# Altium schematic sheet [03] - 28PINS SCHEMATIC.SchDoc("[03] - 28PINS SCHEMATIC") (continued)
## Library data of the parts (continued)
U4-U7 = SN74AUC1G08DBVR: LOGIC, 74AUC1G, AND GATE, SOT23-5; Manufacturer: Texas Instruments; High Level Output Current: -9mA; Low Level Output Current: 9mA; Max Supply Voltage: 2.7V; Min Supply Voltage: 800mV; Number of Bits: 1; Number of Input Lines: 2; Propagation Delay: 2ns; Quiescent Current: 10uA; Schmitt Trigger Input: No; Turn-On Delay Time: 2.4ns; Input Type: DIFFERENTIAL; Case/Package: SOT-23; Category: Logic Gates; Component Kind: Standard; ComponentLink1Description: https://datasheet.ciiva.com/4216/1146sn74auc1g08-4216507.pdf?src-supplier=Arrow+Electronics; ComponentLink1URL: https://datasheet.ciiva.com/4216/1146sn74auc1g08-4216507.pdf?src-supplier=Arrow+Electronics; ComponentLink2Description: https://datasheet.ciiva.com/6124/1775236-6124395.pdf?src-supplier=Farnell; ComponentLink2URL: https://datasheet.ciiva.com/6124/1775236-6124395.pdf?src-supplier=Farnell; ComponentLink3Description: https://datasheet.ciiva.com/23844/1634288-23844654.pdf?src-supplier=Newark; ComponentLink3URL: https://datasheet.ciiva.com/23844/1634288-23844654.pdf?src-supplier=Newark; ComponentLink4Description: https://datasheet.ciiva.com/1864/sn74auc1g08-1864662.pdf?src-supplier=Digi-Key; ComponentLink4URL: https://datasheet.ciiva.com/1864/sn74auc1g08-1864662.pdf?src-supplier=Digi-Key; ComponentLink5Description: https://datasheet.ciiva.com/1864/sn74auc1g08-1864663.pdf?src-supplier=Digi-Key; ComponentLink5URL: https://datasheet.ciiva.com/1864/sn74auc1g08-1864663.pdf?src-supplier=Digi-Key; ComponentLink6Description: https://datasheet.ciiva.com/1864/sn74auc1g08-1864664.pdf?src-supplier=Digi-Key; ComponentLink6URL: https://datasheet.ciiva.com/1864/sn74auc1g08-1864664.pdf?src-supplier=Digi-Key; ComponentLink7Description: https://datasheet.ciiva.com/14292/sn74auc1g08-14292269.pdf?src-supplier=Avnet; ComponentLink7URL: https://datasheet.ciiva.com/14292/sn74auc1g08-14292269.pdf?src-supplier=Avnet; Contact Plating: Gold; Height: 1.3mm; Lead Free: Lead Free; Length: 3.05mm; Library Name: Schlib1.SchLib; Logic Function: AND GATE; Manufacturer Part Number: SN74AUC1G08DBVR; Max Operating Temperature: 85°C; Max Output Current: 9mA; Min Operating Temperature: -40°C; Mount: Surface Mount; Number of Circuits: 1; Number of Elements: 1; Number of Gates: 1; Number of Output Lines: 1; Number of Outputs: 1; Number of Pins: 5; Number of Inputs: 2; Output Current: 9mA; Package Quantity: 3000; Packaging: Tape and Reel; Pricing 1: 1=0,43000, 10=0,34900, 25=0,31920, 100=0,23740, 250=0,21520, 500=0,17808, 1000=0,13356 (USD); Radiation Hardening: No; REACH SVHC: No SVHC; RoHS: Yes; RoHS Compliant: Yes; Series: 74AUC; Stock 1: 21198; Supplier 1: Digi-Key; Supplier Part Number 1: 296-13170-1-ND; Technology: CMOS; Termination: SMD/SMT; Weight: 0.000395oz; Width: 1.75mm
Y1 Y2 = ABM11W-16.0000MHZ-8-B1U-T3: Iot Optimized 16MHZ 8PF Crystal; Manufacturer: Abracon; Category: Crystals; Component Kind: Standard; ComponentLink1Description: https://abracon.com/Resonators/ABM11W.pdf?src-supplier=Digi-Key; ComponentLink1URL: https://abracon.com/Resonators/ABM11W.pdf?src-supplier=Digi-Key; Library Name: Schlib1.SchLib; Manufacturer Part Number: ABM11W-16.0000MHZ-8-B1U-T3; Pricing 1: 1=0,75000, 10=0,66200, 50=0,61920, 100=0,54720, 500=0,51840, 1000=0,43200 (USD); RoHS: Yes; RoHS Compliant: Yes; Stock 1: 1980; Supplier 1: Digi-Key; Supplier Part Number 1: 535-13813-1-ND
Z1 Z2 = CGA0603MLC-05E: ESD Suppressor TVS Bi-Dir 5V Automotive 2-Pin Case 0603(1608Metric) T/R; Manufacturer: Bourns; Capacitance: 0.2pF; Clamping Voltage: 30V; Current Rating: 5nA; Direction: Bidirectional; Element Configuration: Single; ESD Protection: Yes; Leakage Current: 10nA; Number of Circuits: 1; Case Code (Metric): 1608; Case Code (Imperial): 0603; Case/Package: 0603; Category: Varistors; Component Kind: Standard; ComponentLink1Description: https://datasheet.ciiva.com/24142/bourns-cga-mlc-280156-24142144.pdf?src-supplier=Mouser; ComponentLink1URL: https://datasheet.ciiva.com/24142/bourns-cga-mlc-280156-24142144.pdf?src-supplier=Mouser; ComponentLink2Description: https://datasheet.ciiva.com/30479/0900766b81295add-30479028.pdf?src-supplier=RSComponents; ComponentLink2URL: https://datasheet.ciiva.com/30479/0900766b81295add-30479028.pdf?src-supplier=RSComponents; ComponentLink3Description: https://datasheet.ciiva.com/28475/1772768-28475109.pdf?src-supplier=Element14; ComponentLink3URL: https://datasheet.ciiva.com/28475/1772768-28475109.pdf?src-supplier=Element14; ComponentLink4Description: https://datasheet.ciiva.com/30009/cga-mlc-30009944.pdf?src-supplier=Avnet; ComponentLink4URL: https://datasheet.ciiva.com/30009/cga-mlc-30009944.pdf?src-supplier=Avnet; Contact Plating: Tin; Depth: 0.85mm; Height: 0.51mm; Length: 1.6mm; Library Name: Schlib1.SchLib; Manufacturer Part Number: CGA0603MLC-05E; Max Operating Temperature: 125°C; Max Reverse Leakage Current: 5nA; Max Voltage Rating (DC): 5V; Min Operating Temperature: -55°C; Mount: Surface Mount; Number of Pins: 2; Operating Supply Voltage: 5V; Package Quantity: 5000; Packaging: Tape and Reel; Pricing 1: 1=0,58000, 10=0,45400, 25=0,38280, 100=0,31150, 250=0,25808, 500=0,21360, 1000=0,16020, 2500=0,14685 (USD); Radiation Hardening: No; REACH SVHC: No SVHC; RoHS: Yes; RoHS Compliant: Yes; Stock 1: 6992; Supplier 1: Digi-Key; Supplier Part Number 1: CGA0603MLC-05ECT-ND; Termination: SMD/SMT; Voltage Rating (DC): 5V; Width: 0.85mm; Working Voltage: 5V
